# Altium schematic sheet RoomLED.SchDoc(RoomLED)
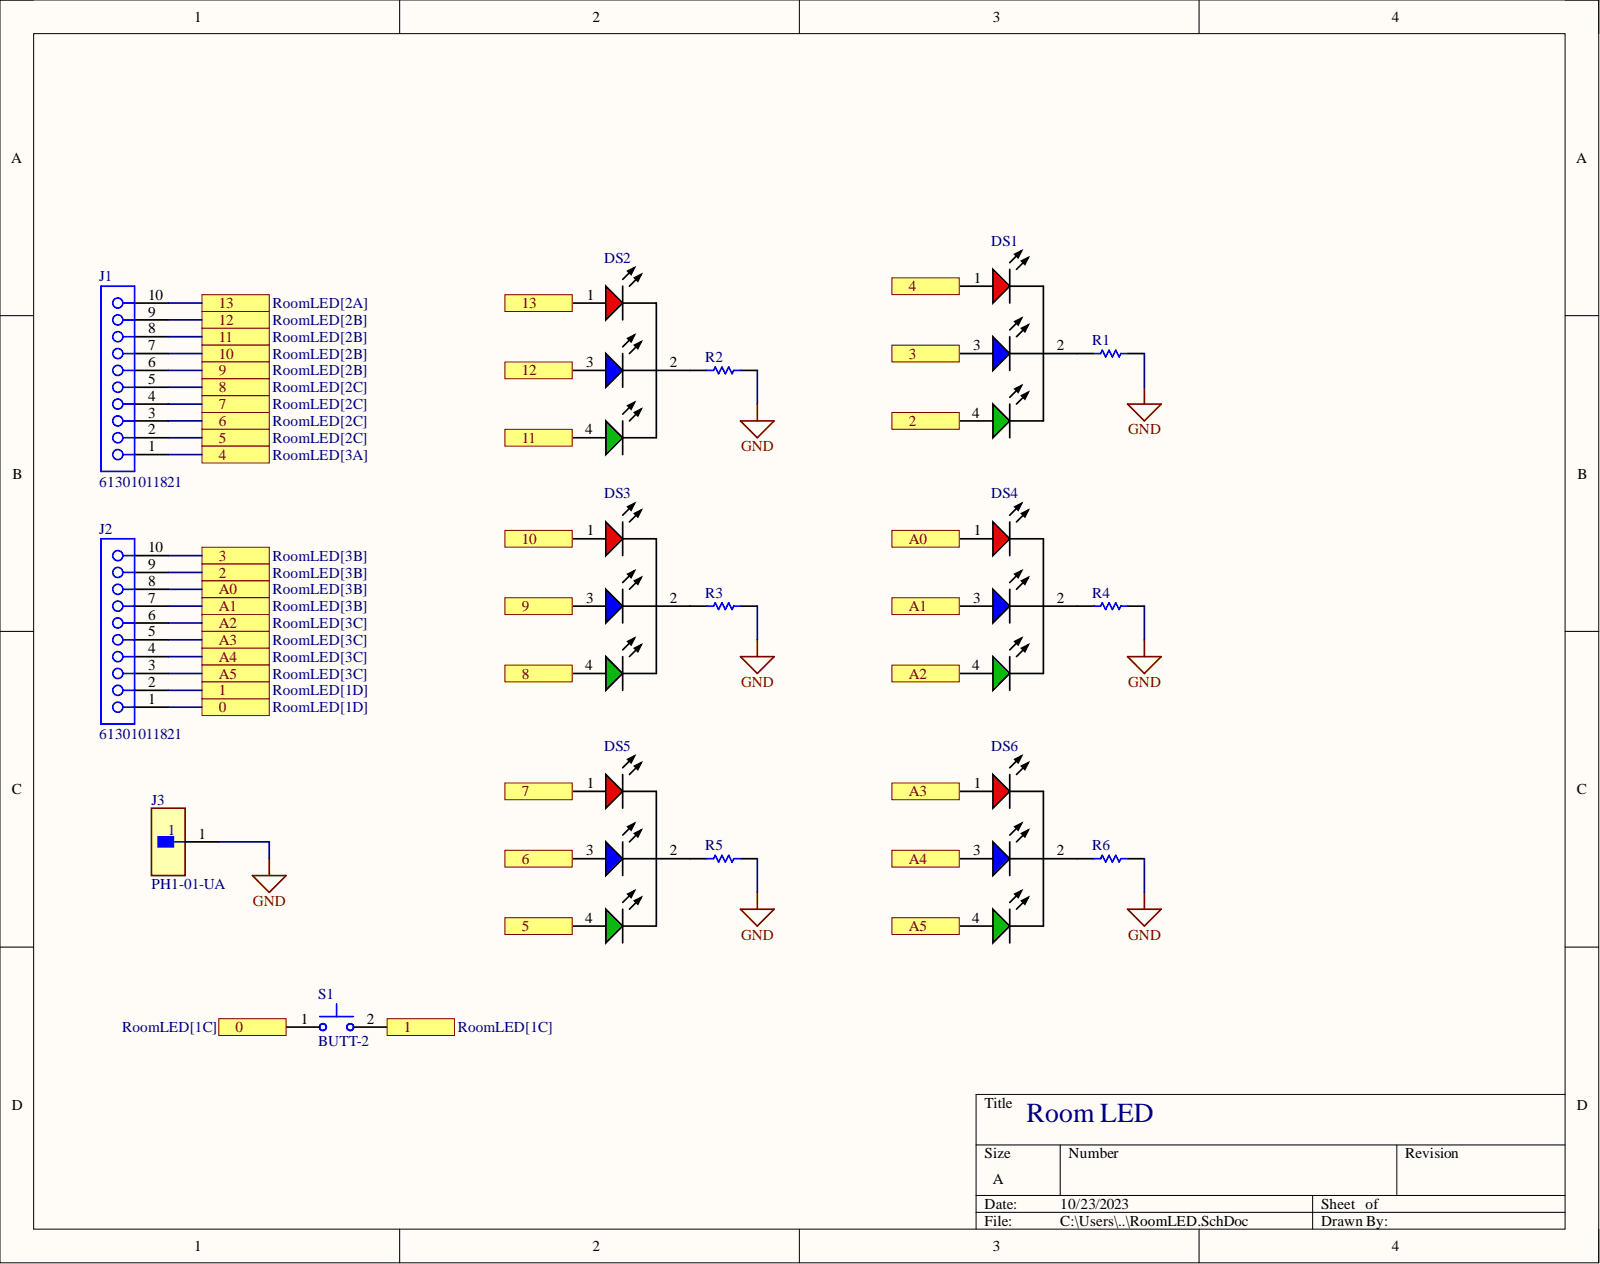
[diagram p0: RoomLED.SchDoc]

## Parts
DS1  WP154A4SUREQBFZGC  [KING-WP154A4SUREQBFZGC_V]  (value not drawn)
DS2  WP154A4SUREQBFZGC  [KING-WP154A4SUREQBFZGC_V]  (value not drawn)
DS3  WP154A4SUREQBFZGC  [KING-WP154A4SUREQBFZGC_V]  (value not drawn)
DS4  WP154A4SUREQBFZGC  [KING-WP154A4SUREQBFZGC_V]  (value not drawn)
DS5  WP154A4SUREQBFZGC  [KING-WP154A4SUREQBFZGC_V]  (value not drawn)
DS6  WP154A4SUREQBFZGC  [KING-WP154A4SUREQBFZGC_V]  (value not drawn)
J1  61301011821  [61301011821]
J2  61301011821  [61301011821]
J3  PH1-01-UA  [FP-PH1-01-UA-MFG]
R1  MFR-25FBF52-100K  [RESA60-630X240]  (value not drawn)
R2  MFR-25FBF52-100K  [RESA60-630X240]  (value not drawn)
R3  MFR-25FBF52-100K  [RESA60-630X240]  (value not drawn)
R4  MFR-25FBF52-100K  [RESA60-630X240]  (value not drawn)
R5  MFR-25FBF52-100K  [RESA60-630X240]  (value not drawn)
R6  MFR-25FBF52-100K  [RESA60-630X240]  (value not drawn)
S1  BUTT-2  [SW_BUTT-2]

## Ports
0, 1, 2, 3, 4, 5, 6, 7, 8, 9, 10, 11, 12, 13, A0, A1, A2, A3, A4, A5

## Nets
GND: J3.1 R1.2 R2.2 R3.2 R4.2 R5.2 R6.2
0: J2.1 S1.1 ; port 0
1: J2.2 S1.2 ; port 1
2: DS1.4 J2.9 ; port 2
3: DS1.3 J2.10 ; port 3
4: DS1.1 J1.1 ; port 4
5: DS5.4 J1.2 ; port 5
6: DS5.3 J1.3 ; port 6
7: DS5.1 J1.4 ; port 7
8: DS3.4 J1.5 ; port 8
9: DS3.3 J1.6 ; port 9
10: DS3.1 J1.7 ; port 10
11: DS2.4 J1.8 ; port 11
12: DS2.3 J1.9 ; port 12
13: DS2.1 J1.10 ; port 13
A0: DS4.1 J2.8 ; port A0
A1: DS4.3 J2.7 ; port A1
A2: DS4.4 J2.6 ; port A2
A3: DS6.1 J2.5 ; port A3
A4: DS6.3 J2.4 ; port A4
A5: DS6.4 J2.3 ; port A5
NetDS1_2: DS1.2 R1.1
NetDS2_2: DS2.2 R2.1
NetDS3_2: DS3.2 R3.1
NetDS4_2: DS4.2 R4.1
NetDS5_2: DS5.2 R5.1
NetDS6_2: DS6.2 R6.1

## Library data of the parts
DS1-DS6 = CMP-2000-07415-1: Full Color LED Lamp, Dominant Wavelength RGB 630 / 525 / 465 nm, -40 to 85 degC, 4-Pin THD, RoHS; Manufacturer: Kingbright; 3D Model: WP154A4SUREQBFZGW.sldprt    WP154A4SUREQBFZGW.pro    WP154A4SUREQBFZGW.igs    WP154A4SUREQBFZGW.stp; Color: Red,Green,Blue(RGB); Current - Test: 20mA; Lens Style/Size: RoundwithDomedTop,5mm,T-13/4; Lens Type: Clear; Millicandela Rating: 1300mcdRed,1700mcdGreen,900mcdBlue; Online Catalog: 5mm,T-13/4(RGB)RoundwithDomedTopLEDLamp; Other Names: 754-1615  WP154A4SUREQBFZGC-ND; Viewing Angle: 50°; Voltage - Forward (Vf) (Typ): 1.9VRed,3.3VGreen,3.3VBlue; Wavelength - Dominant: 630nm,525nm,465nm; Wavelength - Peak: 645nm,515nm,460nm; Category: Optoelectronics; Component Kind: Standard; Datasheet URL: https://www.kingbrightusa.com/images/catalog/spec/WP154A4SUREQBFZGC.pdf; Datasheet Version: Rev. 5A, 08/2014; Family: LEDIndication-Discrete; Height: 8.60mm; Manufacturer URL: http://www.kingbright.com/; Mounting Technology: ThroughHole; Mounting Type: ThroughHole; Package / Case: Radial-4Leads; Package Description: 4-Pin Through Hole LED, Dia 5.9 mm, Pitch 1.27 mm; Package Reference: WP154A4SUREQBFZGC; Packaging: Bulk; Standard Package: 500; Library Name: RoomLED.SchLib
J1 J2 = CMP-1502-01332-2: NexarPartId: 18818160; Connector Type: Header, Socket; Contact Resistance: 20mΩ; Current Rating: 3A; Gender: Female; Insulation: Insulated; Insulation Resistance: 1GΩ; Number of Contacts: 10; Operating Supply Voltage: 250V; Pitch: 2.54mm; Color: Black; Component Kind: Standard; Contact Gender: Female; Contact Material: Copper; Contact Plating: Gold; Flammability Rating: UL94 V-0; Height: 8.5mm; Housing Material: PBT; Lead Free: Lead Free; Lead Pitch: 2.54mm; Length: 25.9mm; Library Name: Altium Content Vault; Max Operating Temperature: 125°C; Min Operating Temperature: -40°C; Mount: Through Hole; Number of Pins: 10; Number of Positions: 10; Number of Rows: 1; Orientation: Vertical; REACH SVHC: Unknown; RoHS: Compliant; Termination: Through Hole; Voltage Rating: 250V; Width: 25.9mm
J3 = CMP-42765-000003-1: CONN HEADER VERT 1POS 2.54MM; Number of Positions: 1; Voltage Rating (AC): 250V; Component Kind: Standard; Connector Type: Header; Contact Finish - Mating: GOLD; Contact Material: Brass; Contact Shape: Square; Contact Type: Male Pin; Fastening Type: Pull; Flammability Rating: UL94 V-0; Insulation Color: Black; Insulation Material: PBT; Lead Free: Lead Free; Library Name: Altium Content Vault; Max Operating Temperature: 105°C; Min Operating Temperature: -40°C; Mount: Through Hole; Number of Rows: 1; Packaging: Bulk; Series: PH1; Termination: Solder
R1-R6 = CMP-1659-00073-1: Axial Resistor, 100 KOhm, +/- 1%, 0.25 W, -55 to 155 degC, 2-Pin THD, RoHS, Bulk; Power Rating: 250 mW; Resistance: 475 Ohm; Voltage Rating: 250 V; Case\Package: Axial; Component Kind: Standard; ComponentLink1Description: Manufacturer URL; ComponentLink1URL: http://www.yageo.com/; ComponentLink2Description: Datasheet; ComponentLink2URL: http://www.yageo.com/documents/recent/Yageo%20LR_MFR_2013.pdf; DatasheetVersion: Apr-13; Length: 0.248 inch; Max Operating Temperature: 155 degC; Min Operating Temperature: -55 degC; Mount: Through Hole; Package Quantity: 200; PackageDescription: 2-Pin Axial Lead, Dia 2.4 mm, Body Length 6.3 mm; PackageReference: MFR-25; Packaging: Bulk; RoHSCompliant: true; Tolerance: 1%; Library Name: RoomLED.SchLib
S1 = BUTT-2: Tactile Switches MINI PUSH BUTTON SWITCH 2-PIN QTY. 4; MF: Gravitech; Availability: In Stock; Check_prices: https://www.snapeda.com/parts/BUTT-2/Gravitech/view-part/?ref=eda; Component Kind: Standard; MP: BUTT-2; Package: None; Price: None; Purchase-URL: https://pricing.snapeda.com/search/part/BUTT-2/?ref=eda; SnapEDA_Link: https://www.snapeda.com/parts/BUTT-2/Gravitech/view-part/?ref=snap; Library Name: RoomLED.SchLib
